# Altium schematic sheet AUDINT003_RevA_Power.SchDoc(AUDINT003_RevA_Power)
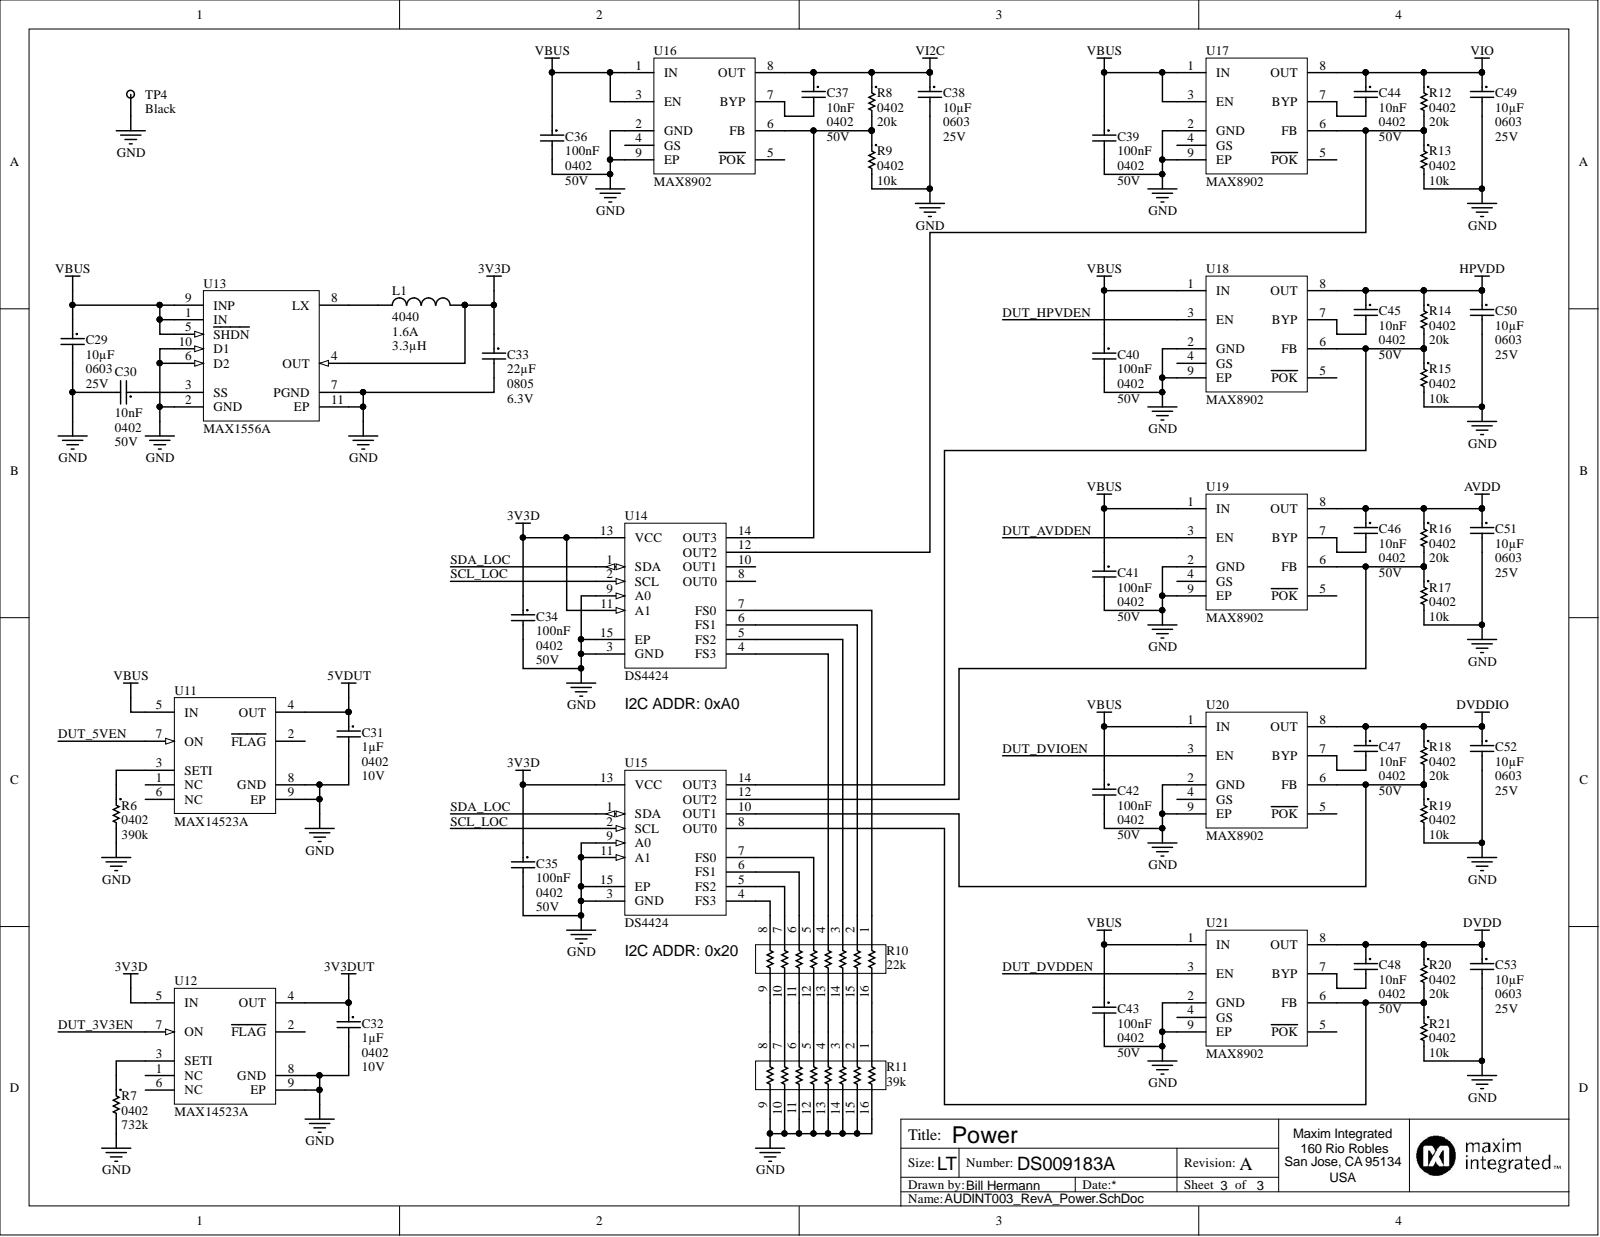
[diagram p0: AUDINT003_RevA_Power.SchDoc]

## Parts
C29  value 10µF  Package: 0603  [CAPC0603(1608)55_N]  (hidden comment: Capacitor)
C30  value 10nF  Package: 0402  [CAPC0402(1005)60_L]  (hidden comment: Capacitor)
C31  value 1µF  Voltage: 10V  Package: 0402  [CAPC0402(1005)60_L]  (hidden comment: Capacitor)
C32  value 1µF  Voltage: 10V  Package: 0402  [CAPC0402(1005)60_L]  (hidden comment: Capacitor)
C33  value 22µF  Voltage: 6.3V  Package: 0805  [CAPC0805(2012)75_N]  (hidden comment: Capacitor)
C34  value 100nF  Voltage: 50V  Package: 0402  [CAPC0402(1005)60_L]  (hidden comment: Capacitor)
C35  value 100nF  Voltage: 50V  Package: 0402  [CAPC0402(1005)60_L]  (hidden comment: Capacitor)
C36  value 100nF  Voltage: 50V  Package: 0402  [CAPC0402(1005)60_L]  (hidden comment: Capacitor)
C37  value 10nF  Voltage: 50V  Package: 0402  [CAPC0402(1005)60_L]  (hidden comment: Capacitor)
C38  value 10µF  Voltage: 25V  Package: 0603  [CAPC0603(1608)55_N]  (hidden comment: Capacitor)
C39  value 100nF  Voltage: 50V  Package: 0402  [CAPC0402(1005)60_L]  (hidden comment: Capacitor)
C40  value 100nF  Voltage: 50V  Package: 0402  [CAPC0402(1005)60_L]  (hidden comment: Capacitor)
C41  value 100nF  Voltage: 50V  Package: 0402  [CAPC0402(1005)60_L]  (hidden comment: Capacitor)
C42  value 100nF  Voltage: 50V  Package: 0402  [CAPC0402(1005)60_L]  (hidden comment: Capacitor)
C43  value 100nF  Voltage: 50V  Package: 0402  [CAPC0402(1005)60_L]  (hidden comment: Capacitor)
C44  value 10nF  Voltage: 50V  Package: 0402  [CAPC0402(1005)60_L]  (hidden comment: Capacitor)
C45  value 10nF  Voltage: 50V  Package: 0402  [CAPC0402(1005)60_L]  (hidden comment: Capacitor)
C46  value 10nF  Voltage: 50V  Package: 0402  [CAPC0402(1005)60_L]  (hidden comment: Capacitor)
C47  value 10nF  Voltage: 50V  Package: 0402  [CAPC0402(1005)60_L]  (hidden comment: Capacitor)
C48  value 10nF  Voltage: 50V  Package: 0402  [CAPC0402(1005)60_L]  (hidden comment: Capacitor)
C49  value 10µF  Voltage: 25V  Package: 0603  [CAPC0603(1608)55_N]  (hidden comment: Capacitor)
C50  value 10µF  Voltage: 25V  Package: 0603  [CAPC0603(1608)55_N]  (hidden comment: Capacitor)
C51  value 10µF  Voltage: 25V  Package: 0603  [CAPC0603(1608)55_N]  (hidden comment: Capacitor)
C52  value 10µF  Voltage: 25V  Package: 0603  [CAPC0603(1608)55_N]  (hidden comment: Capacitor)
C53  value 10µF  Voltage: 25V  Package: 0603  [CAPC0603(1608)55_N]  (hidden comment: Capacitor)
L1  value 3.3µH  Package: 4040  Current: 1.6A  [Bourns_SRN2046]  (hidden comment: Inductor)
R6  value 390k  Package: 0402  [RESC0402(1005)_L]  (hidden comment: Res / 390kΩ / 1% / 0402)
R7  value 732k  Package: 0402  [RESC0402(1005)_L]  (hidden comment: Res / 732kΩ / 1% / 0402)
R8  value 20k  Package: 0402  [RESC0402(1005)_L]  (hidden comment: Res / 20kΩ / 0.5% / 0402)
R9  value 10k  Package: 0402  [RESC0402(1005)_L]  (hidden comment: Res / 10kΩ / 0.5% / 0402)
R10  value 22k  [741X163]  (hidden comment: RES_22k_ARRAY8)
R11  value 39k  [741X163]  (hidden comment: RES_39k_ARRAY8)
R12  value 20k  Package: 0402  [RESC0402(1005)_L]  (hidden comment: Res / 20kΩ / 0.5% / 0402)
R13  value 10k  Package: 0402  [RESC0402(1005)_L]  (hidden comment: Res / 10kΩ / 0.5% / 0402)
R14  value 20k  Package: 0402  [RESC0402(1005)_L]  (hidden comment: Res / 20kΩ / 0.5% / 0402)
R15  value 10k  Package: 0402  [RESC0402(1005)_L]  (hidden comment: Res / 10kΩ / 0.5% / 0402)
R16  value 20k  Package: 0402  [RESC0402(1005)_L]  (hidden comment: Res / 20kΩ / 0.5% / 0402)
R17  value 10k  Package: 0402  [RESC0402(1005)_L]  (hidden comment: Res / 10kΩ / 0.5% / 0402)
R18  value 20k  Package: 0402  [RESC0402(1005)_L]  (hidden comment: Res / 20kΩ / 0.5% / 0402)
R19  value 10k  Package: 0402  [RESC0402(1005)_L]  (hidden comment: Res / 10kΩ / 0.5% / 0402)
R20  value 20k  Package: 0402  [RESC0402(1005)_L]  (hidden comment: Res / 20kΩ / 0.5% / 0402)
R21  value 10k  Package: 0402  [RESC0402(1005)_L]  (hidden comment: Res / 10kΩ / 0.5% / 0402)
TP4  Color: Black  [5011K-ND_TP_BLACK]  (hidden comment: TP_BLACK_5011)
U11  MAX14523A  [T833+2]
U12  MAX14523A  [T833+2]
U13  MAX1556A  [T1033-1_V]
U14  DS4424  [T1433+2_N]
U15  DS4424  [T1433+2_N]
U16  MAX8902  [T822+1_N]
U17  MAX8902  [T822+1_N]
U18  MAX8902  [T822+1_N]
U19  MAX8902  [T822+1_N]
U20  MAX8902  [T822+1_N]
U21  MAX8902  [T822+1_N]

## Nets
3V3D: C33.1 C34.1 C35.1 L1.2 U12.5(IN) U13.4(OUT) U14.11(A1) U14.13(VCC) U15.13(VCC)
3V3DUT: C32.1 U12.4(OUT)
5VDUT: C31.1 U11.4(OUT)
AVDD: C46.1 C51.1 R16.1 U19.8(OUT)
DVDD: C48.1 C53.1 R20.1 U21.8(OUT)
DVDDIO: C47.1 C52.1 R18.1 U20.8(OUT)
GND: C29.2 C30.2 C31.2 C32.2 C33.2 C34.2 C35.2 C36.2 C38.2 C39.2 C40.2 C41.2 C42.2 C43.2 C49.2 C50.2 C51.2 C52.2 C53.2 R6.2 R7.2 R9.2 R11.9 R11.10 R11.11 R11.12 R11.13 R11.14 R11.15 R11.16 R13.2 R15.2 R17.2 R19.2 R21.2 TP4.1 U11.8(GND) U11.9(EP) U12.8(GND) U12.9(EP) U13.2(GND) U13.6(D2) U13.7(PGND) U13.10(D1) U13.11(EP) U14.3(GND) U14.9(A0) U14.15(EP) U15.3(GND) U15.9(A0) U15.11(A1) U15.15(EP) U16.2(GND) U16.9(EP) U17.2(GND) U17.9(EP) U18.2(GND) U18.9(EP) U19.2(GND) U19.9(EP) U20.2(GND) U20.9(EP) U21.2(GND) U21.9(EP)
HPVDD: C45.1 C50.1 R14.1 U18.8(OUT)
VBUS: C29.1 C36.1 C39.1 C40.1 C41.1 C42.1 C43.1 U11.5(IN) U13.1(IN) U13.5(SHDN) U13.9(INP) U16.1(IN) U16.3(EN) U17.1(IN) U17.3(EN) U18.1(IN) U19.1(IN) U20.1(IN) U21.1(IN)
VI2C: C37.1 C38.1 R8.1 U16.8(OUT)
VIO: C44.1 C49.1 R12.1 U17.8(OUT)
DUT_3V3EN: U12.7(ON)
DUT_5VEN: U11.7(ON)
DUT_AVDDEN: U19.3(EN)
DUT_DVDDEN: U21.3(EN)
DUT_DVIOEN: U20.3(EN)
DUT_HPVDEN: U18.3(EN)
SCL_LOC: U14.2(SCL) U15.2(SCL)
SDA_LOC: U14.1(SDA) U15.1(SDA)
NetC30_1: C30.1 U13.3(SS)
NetC37_2: C37.2 U16.7(BYP)
NetC44_2: C44.2 U17.7(BYP)
NetC45_2: C45.2 U18.7(BYP)
NetC46_2: C46.2 U19.7(BYP)
NetC47_2: C47.2 U20.7(BYP)
NetC48_2: C48.2 U21.7(BYP)
NetL1_1: L1.1 U13.8(LX)
NetR6_1: R6.1 U11.3(SETI)
NetR7_1: R7.1 U12.3(SETI)
NetR8_2: R8.2 R9.1 U14.14(OUT3) U16.6(FB)
NetR10_1: R10.1 U14.7(FS0)
NetR10_2: R10.2 U14.6(FS1)
NetR10_3: R10.3 U14.5(FS2)
NetR10_4: R10.4 U14.4(FS3)
NetR10_5: R10.5 U15.7(FS0)
NetR10_6: R10.6 U15.6(FS1)
NetR10_7: R10.7 U15.5(FS2)
NetR10_8: R10.8 U15.4(FS3)
NetR10_9: R10.9 R11.8
NetR10_10: R10.10 R11.7
NetR10_11: R10.11 R11.6
NetR10_12: R10.12 R11.5
NetR10_13: R10.13 R11.4
NetR10_14: R10.14 R11.3
NetR10_15: R10.15 R11.2
NetR10_16: R10.16 R11.1
NetR12_2: R12.2 R13.1 U14.12(OUT2) U17.6(FB)
NetR14_2: R14.2 R15.1 U15.14(OUT3) U18.6(FB)
NetR16_2: R16.2 R17.1 U15.12(OUT2) U19.6(FB)
NetR18_2: R18.2 R19.1 U15.10(OUT1) U20.6(FB)
NetR20_2: R20.2 R21.1 U15.8(OUT0) U21.6(FB)

Not connected: U11 1(NC) 2(FLAG) 6(NC); U12 1(NC) 2(FLAG) 6(NC); U14 8(OUT0) 10(OUT1); U16 4(GS) 5(POK); U17 4(GS) 5(POK); U18 4(GS) 5(POK); U19 4(GS) 5(POK); U20 4(GS) 5(POK); U21 4(GS) 5(POK)

## Library data of the parts
C29 C38 C49-C53 = CAP_10µF_25V_0603: Capacitor / Ceramic / 10µF / 25V / X5R / 0603; Manufacturer PN: GRM188R61E106MA73D; Manufacturer: Murata; Tolerance: 20%; Simulation: CAP; Library Name: AUDINT002.SchLib; Dielectric: X5R; Component Kind: Standard
  C29: Voltage: 25V
C30 C37 C44-C48 = CAP_10nF_50V_0402: Capacitor / Ceramic / 10nF / 50V / X7R / 0603; Manufacturer PN: GRM155R71H103KA88D; Manufacturer: Murata; Tolerance: 10%; Simulation: CAP; Mouser: 81-GRM155R71H103KA8D; Library Name: AUDINT002.SchLib; DigiKey: 490-4516-1-ND; Dielectric: X7R; Component Kind: Standard
  C30: Voltage: 50V
C31 C32 = CAP_1uF_10V_0402: Capacitor / Ceramic / 1µF / 10V / X5R / 0402; Manufacturer PN: GRM155R61A105KE15D; Manufacturer: Murata; Tolerance: 10%; Simulation: CAP; Mouser: 81-GRM155R61A105KE15; Library Name: AUDINT002.SchLib; DigiKey: 490-3890-1-ND; Dielectric: X5R; Component Kind: Standard
C33 = CAP_22µF_6V3_0805: Capacitor / Ceramic / 22µF / 6.3V / X5R / 0805; Manufacturer PN: C2012X5R0J226K125AB; Manufacturer: TDK; Tolerance: 10%; Simulation: CAP; Mouser: 810-C2012X5R0J226K-3; Library Name: AUDINT002.SchLib; DigiKey: 445-7679-1-ND; Dielectric: X5R; Component Kind: Standard
C34-C36 C39-C43 = CAP_100nF_50V_0402: Capacitor / Ceramic / 100nF / 50V / X5R / 0402; Manufacturer PN: UMK105BJ104KV-F; Manufacturer: Taiyo Yuden; Tolerance: 10%; Simulation: CAP; Mouser: 963-UMK105BJ104KV-F; Library Name: AUDINT002.SchLib; Dielectric: X5R; Component Kind: Standard
L1 = IND_3µ3H_1A6_4040: Inductor / 3.3µH / 1.6A / 20% / 4040; Manufacturer PN: SRN4026-3R3M; Manufacturer: Bourns; Tolerance: 20%; Simulation: INDUCTOR; Signal Integrity: Inductor; Mouser: 652-SRN4026-3R3M; Library Name: AUDINT002.SchLib; DigiKey: SRN4026-3R3MCT-ND; Component Kind: Standard
R6 = RES_390k_0402: Resistor / Thick Film / 390kΩ / 1% / 0402; Manufacturer PN: RC0402FR-07390KL; Manufacturer: Yageo; Power: 1/16W; Tolerance: 1%; Simulation: RESISTOR; Mouser: 603-RC0402FR-07390KL; Library Name: AUDINT002.SchLib; DigiKey: YAG3143CT-ND; Component Kind: Standard
R7 = RES_732k_0402: Resistor / Thick Film / 732kΩ / 1% / 0402; Manufacturer PN: RC0402FR-07732KL; Manufacturer: Yageo; Power: 1/16W; Tolerance: 1%; Simulation: RESISTOR; Mouser: 603-RC0402FR-07732KL; Library Name: AUDINT002.SchLib; DigiKey: YAG3224CT-ND; Component Kind: Standard
R8 R12 R14 R16 R18 R20 = RES_20k_0%5_0402: Resistor / Thin Film / 20kΩ / 0.5% / 0402; Manufacturer PN: RR0510P-203-D; Manufacturer: Susumu; Tolerance: 1%; Power: 1/16W; Simulation: RESISTOR; Mouser: 754-RR0510P-203D; Library Name: AUDINT002.SchLib; DigiKey: RR05P20.0KDCT-ND; Component Kind: Standard
R9 R13 R15 R17 R19 R21 = RES_10k_0%5_0402: Resistor / Thin Film / 10kΩ / 0.5% / 0402; Manufacturer PN: RR0510P-103-D; Manufacturer: Susumu; Tolerance: 1%; Power: 1/16W; Simulation: RESISTOR; Mouser: 754-RR0510P-103D; Library Name: AUDINT002.SchLib; DigiKey: RR05P10.0KDCT-ND; Component Kind: Standard
R10 = RES_22k_ARRAY8: Resistor Array / Thick Film / 22kΩ / 0402x8; Manufacturer PN: EXB-2HV223JV; Manufacturer: Panasonic; Tolerance: 5%; Power: 1/16W; Package: 0402x8; Mouser: 667-EXB-2HV223JV; Library Name: AUDINT002.SchLib; DigiKey: Y1223CT-ND; Component Kind: Standard
R11 = RES_39k_ARRAY8: Resistor Array / Thick Film / 39kΩ / 0402x8; Manufacturer PN: EXB-2HV393JV; Manufacturer: Panasonic; Tolerance: 5%; Power: 1/16W; Package: 0402x8; Mouser: 667-EXB-2HV393JV; Library Name: AUDINT002.SchLib; DigiKey: Y1393CT-ND; Component Kind: Standard
TP4 = TP_BLACK_5011: Manufacturer PN: 5011; Manufacturer: Keystone Electronics; Mouser: 534-5011; Library Name: AUDINT002.SchLib; DigiKey: 5011K-ND; Component Kind: Standard
U11 U12 = MAX14523A: 250mA to 1.5A, Adjustable Current-Limit Switches; Manufacturer PN: MAX14523AATA+; Manufacturer: Maxim; Package: TDFN8-EP; Library Name: AUDINT002.SchLib; Component Kind: Standard
U13 = MAX1556A: 16μA IQ, 1.2A PWM  Step-Down DC-DC Converters; Manufacturer PN: MAX1556AETB+; Manufacturer: Maxim; Package: TDFN10EP; Library Name: AUDINT002.SchLib; Component Kind: Standard
U14 U15 = DS4424: Quad Current Output DAC with I2C Interface; Manufacturer PN: DS4424N+; Manufacturer: Maxim; Package: TDFN14-EP; Library Name: AUDINT002.SchLib; Component Kind: Standard
U16-U21 = MAX8902: Low-noise 500mA LDO; Manufacturer PN: MAX8902BATA+; Manufacturer: Maxim; Package: TDFN8; Library Name: AUDINT002.SchLib; Component Kind: Standard
